# Altium schematic sheet PowerConnections.SchDoc
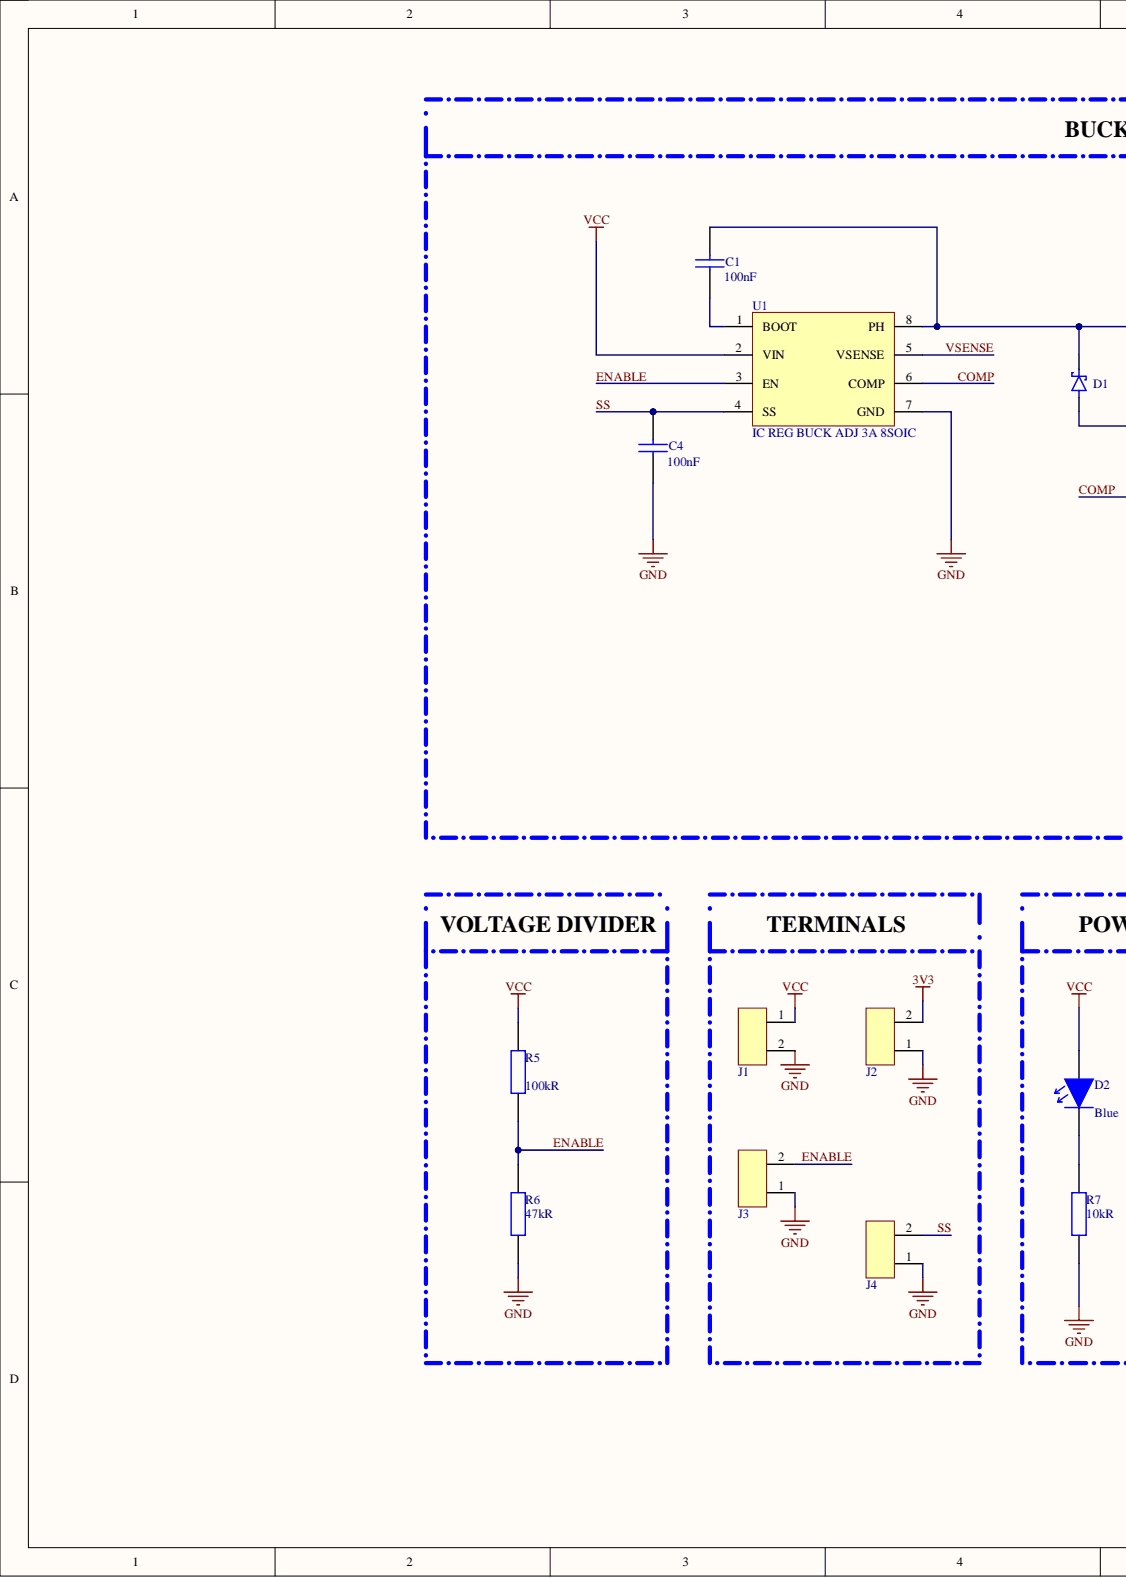
[diagram p0: PowerConnections.SchDoc, left]
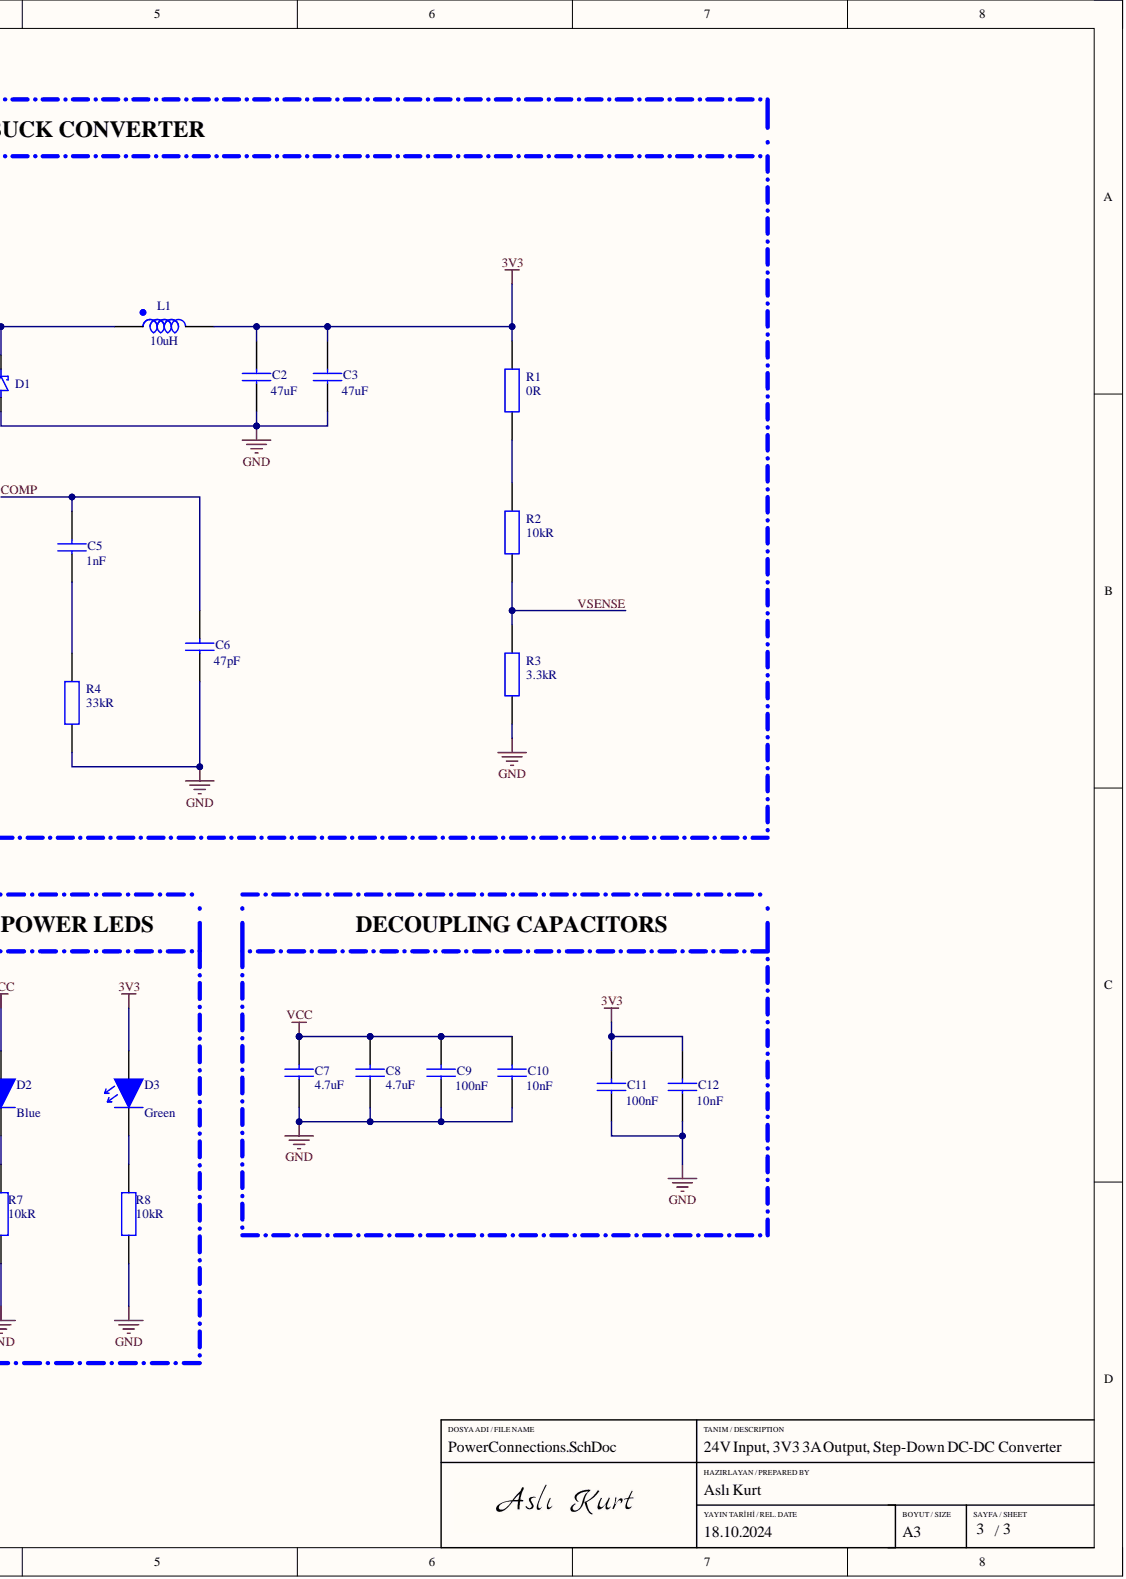
[diagram p1: PowerConnections.SchDoc, right]

## Parts
C1  Capacitance: 100nF  [CAP_1206]  (hidden comment: C1206C104J5RAC7800)
C2  Capacitance: 47uF  [CAP_1206]  (hidden comment: GMC31X5R476M25NT)
C3  Capacitance: 47uF  [CAP_1206]  (hidden comment: GMC31X5R476M25NT)
C4  Capacitance: 100nF  [CAP_1206]  (hidden comment: C1206C104J5RAC7800)
C5  Capacitance: 1nF  [CAP_1206]  (hidden comment: C3216C0G2J102J085AA)
C6  Capacitance: 47pF  [CAP_1206]  (hidden comment: C1206C470J5GAC7800)
C7  Capacitance: 4.7uF  [CAP_0805]  (hidden comment: CL21A475KAQNNNE)
C8  Capacitance: 4.7uF  [CAP_0805]  (hidden comment: CL21A475KAQNNNE)
C9  Capacitance: 100nF  [CAP_1206]  (hidden comment: C1206C104J5RAC7800)
C10  Capacitance: 10nF  [CAP_1206]  (hidden comment: C3216C0G2J103J160AA)
C11  Capacitance: 100nF  [CAP_1206]  (hidden comment: C1206C104J5RAC7800)
C12  Capacitance: 10nF  [CAP_1206]  (hidden comment: C3216C0G2J103J160AA)
D1  B340AE-13  [SMA]  (value not drawn)
D2  Color: Blue  [LED_BLUE_1206]  (hidden comment: 150120BS75000)
D3  Color: Green  [LED_GREEN_1206]  (hidden comment: 150120GS75000)
J1  282836-2  [TERMINAL]  (value not drawn)
J2  282836-2  [TERMINAL]  (value not drawn)
J3  2x1 Male Header 2.54mm  [2x1 Male Header 2.54mm]  (value not drawn)
J4  2x1 Male Header 2.54mm  [2x1 Male Header 2.54mm]  (value not drawn)
L1  Inductance: 10uH  [IND_1010]  (hidden comment: SRI1004)
R1  Resistance: 0R  [RES_1206]  (hidden comment: PMR18EZPJ000)
R2  Resistance: 10kR  [RES_1206]  (hidden comment: RC1206JR-0710KL)
R3  Resistance: 3.3kR  [RES_1206]  (hidden comment: RC1206JR-073K3L)
R4  Resistance: 33kR  [RES_1206]  (hidden comment: RES 33K OHM 5% 1/4W 1206)
R5  Resistance: 100kR  [RES_1206]  (hidden comment: RC1206JR-07100KL)
R6  Resistance: 47kR  [RES_1206]  (hidden comment: RES 47K OHM 5% 1/4W 1206)
R7  Resistance: 10kR  [RES_1206]  (hidden comment: RC1206JR-0710KL)
R8  Resistance: 10kR  [RES_1206]  (hidden comment: RC1206JR-0710KL)
U1  IC REG BUCK ADJ 3A 8SOIC  Max Output Current: 3A  [SOIC8_PAD]

## Nets
3V3: C2.2 C3.2 C11.2 C12.2 D3.2 J2.2 L1.2 R1.2
GND: C2.1 C3.1 C4.1 C6.1 C7.1 C8.1 C9.1 C10.1 C11.1 C12.1 D1.1 J1.2 J2.1 J3.1 J4.1 R3.1 R4.1 R6.1 R7.1 R8.1 U1.7(GND)
VCC: C7.2 C8.2 C9.2 C10.2 D2.2 J1.1 R5.2 U1.2(VIN)
COMP: C5.2 C6.2 U1.6(COMP)
ENABLE: J3.2 R5.1 R6.2 U1.3(EN)
SS: C4.2 J4.2 U1.4(SS)
VSENSE: R2.1 R3.2 U1.5(VSENSE)
NetC1_1: C1.1 U1.1(BOOT)
NetC1_2: C1.2 D1.2 L1.1 U1.8(PH)
NetC5_1: C5.1 R4.2
NetD2_1: D2.1 R7.2
NetD3_1: D3.1 R8.2
NetR1_1: R1.1 R2.2

## Library data of the parts
C1 C4 C9 C11 = CAP CER 100nF 1206: CAP CER 0.1UF 50V X7R 1206; Manufacturer: Kyocera AVX; Manufacturer Part Number: 12065C104JAT2A; DesignedBy: Aslı Kurt; Material: 4241ConductorMaterial; Tolerance: 5%; Case Code (Metric): 3216; Case Code (Imperial): 1206; Case/Package: 1206; Category: Ceramic Capacitors; Component Kind: Standard; ComponentLink1Description: https://datasheet.ciiva.com/26915/getdatasheetpartid-87553-26915356.pdf?src-supplier=Verical; ComponentLink1URL: https://datasheet.ciiva.com/26915/getdatasheetpartid-87553-26915356.pdf?src-supplier=Verical; ComponentLink2Description: https://datasheet.ciiva.com/27747/740463-27747550.pdf?src-supplier=Jameco; ComponentLink2URL: https://datasheet.ciiva.com/27747/740463-27747550.pdf?src-supplier=Jameco; ComponentLink3Description: https://datasheet.ciiva.com/7094/1818074-7094222.pdf?src-supplier=Newark; ComponentLink3URL: https://datasheet.ciiva.com/7094/1818074-7094222.pdf?src-supplier=Newark; ComponentLink4Description: https://datasheet.ciiva.com/11463/cx7r-11463226.pdf?src-supplier=Avnet; ComponentLink4URL: https://datasheet.ciiva.com/11463/cx7r-11463226.pdf?src-supplier=Avnet; ComponentLink5Description: https://datasheet.ciiva.com/16318/cx7r-16318250.pdf?src-supplier=Future+Electronics; ComponentLink5URL: https://datasheet.ciiva.com/16318/cx7r-16318250.pdf?src-supplier=Future+Electronics; ComponentLink6Description: https://datasheet.ciiva.com/11505/cx7r-11505336.pdf?src-supplier=Avnet; ComponentLink6URL: https://datasheet.ciiva.com/11505/cx7r-11505336.pdf?src-supplier=Avnet; ComponentLink7Description: https://datasheet.ciiva.com/7833/84526-7833657.pdf?src-supplier=Element14; ComponentLink7URL: https://datasheet.ciiva.com/7833/84526-7833657.pdf?src-supplier=Element14; ComponentLink8Description: https://datasheet.ciiva.com/8081/1679506-8081368.pdf?src-supplier=Element14; ComponentLink8URL: https://datasheet.ciiva.com/8081/1679506-8081368.pdf?src-supplier=Element14; ComponentLink9Description: https://datasheet.ciiva.com/7833/cx7r-7833399.pdf?src-supplier=Element14; ComponentLink9URL: https://datasheet.ciiva.com/7833/cx7r-7833399.pdf?src-supplier=Element14; ComponentLink10Description: https://datasheet.ciiva.com/152/cx7r-152041.pdf?src-supplier=Digikey; ComponentLink10URL: https://datasheet.ciiva.com/152/cx7r-152041.pdf?src-supplier=Digikey; ComponentLink11Description: https://datasheet.ciiva.com/152/cx7r-152040.pdf?src-supplier=Digikey; ComponentLink11URL: https://datasheet.ciiva.com/152/cx7r-152040.pdf?src-supplier=Digikey; ComponentLink12Description: https://datasheet.ciiva.com/152/cx7r-152042.pdf?src-supplier=Digikey; ComponentLink12URL: https://datasheet.ciiva.com/152/cx7r-152042.pdf?src-supplier=Digikey; ComponentLink13Description: https://datasheet.ciiva.com/14604/70001075-14604380.pdf?src-supplier=Allied+Electronics; ComponentLink13URL: https://datasheet.ciiva.com/14604/70001075-14604380.pdf?src-supplier=Allied+Electronics; Dielectric: X7R; Height: 0.5mm; Lead Free: 5697LeadFree; Length: 3.2mm; Library Name: Capacitors.IntLib; Max Operating Temperature: 125°C; Min Operating Temperature: -55°C; Mount: Surface Mount; Package Quantity: 4000; Packaging: Cut Tape; Pricing 1: 4000=0,049, 12000=0,048 (GBP); Pricing 2: 4000=0,04296 (USD); Pricing 3: 4000=0,0373, 12000=0,0364, 20000=0,036, 60000=0,0351, 100000=0,0344 (USD); Pricing 4: 1=0,22, 10=0,105, 50=0,105, 100=0,066, 1000=0,062, 10000=0,041 (USD); RoHS: Yes; RoHS Compliant: Yes; Series: -; Stock 1: 8700; Stock 2: 40000; Stock 3: 916000; Stock 4: 79343; Supplier 1: Rs; Supplier 2: Avnet; Supplier 3: Future Electronics; Supplier 4: Mouser; Supplier Part Number 1: 1441626; Supplier Part Number 2: KGM31BR71H104JT; Supplier Part Number 3: 1247949; Supplier Part Number 4: 581-12065C104J; Termination: SMD/SMT; Voltage Rating: 50V; Voltage Rating (DC): 50V; Weight: 0.000571oz; Width: 1.6mm
C2 C3 = CAP CER 47uF 1206: CAP CER 47UF 25V X5R 1206; Manufacturer: Cal-Chip Electronics; DesignedBy: Aslı Kurt; Tolerance: 20%; Case/Package: 1206; Category: Ceramic Capacitors; Component Kind: Standard; ComponentLink1Description: http://www.calchipelectronics.com/pdf/gmc_series.pdf?src-supplier=Digikey; ComponentLink1URL: http://www.calchipelectronics.com/pdf/gmc_series.pdf?src-supplier=Digikey; Library Name: Capacitors.IntLib; Manufacturer Part Number: GMC31X5R476M25NT; Max Operating Temperature: 85°C; Min Operating Temperature: -55°C; Mount: Surface Mount; Pricing 1: 1=0,99, 10=0,613, 50=0,4602, 100=0,4126, 500=0,33138, 1000=0,30602 (USD); Pricing 2: 1=0,99, 10=0,613, 50=0,4602, 100=0,4126, 500=0,33138, 1000=0,30602 (USD); Pricing 3: 2000=0,28788, 4000=0,27032, 6000=0,26149, 10000=0,25165, 14000=0,24588, 20000=0,24032 (USD); RoHS: Yes; Series: GMC; Stock 1: 38887; Stock 2: 38887; Stock 3: 38000; Supplier 1: Digikey; Supplier 2: Digikey; Supplier 3: Digikey; Supplier Part Number 1: 4713-GMC31X5R476M25NTCT-ND; Supplier Part Number 2: 4713-GMC31X5R476M25NTDKR-ND; Supplier Part Number 3: 4713-GMC31X5R476M25NTTR-ND; Voltage Rating: 25V
C5 = CAP CER 1nF 1206: Manufacturer: TDK; DesignedBy: Aslı Kurt; Material: 4241ConductorMaterial; Category: Ceramic Capacitors; Component Kind: Standard; ComponentLink1Description: https://datasheet.ciiva.com/24219/c3216c0g2j102j085aa-265301-24219668.pdf?src-supplier=Mouser; ComponentLink1URL: https://datasheet.ciiva.com/24219/c3216c0g2j102j085aa-265301-24219668.pdf?src-supplier=Mouser; ComponentLink2Description: https://datasheet.ciiva.com/11788/0900766b80fc5cba-11788148.pdf?src-supplier=Rs; ComponentLink2URL: https://datasheet.ciiva.com/11788/0900766b80fc5cba-11788148.pdf?src-supplier=Rs; ComponentLink3Description: https://datasheet.ciiva.com/7726/1484596-7726604.pdf?src-supplier=Element14; ComponentLink3URL: https://datasheet.ciiva.com/7726/1484596-7726604.pdf?src-supplier=Element14; Description: CAP CER 1nF 1206; Library Name: Capacitors.IntLib; Manufacturer Part Number: C3216C0G2J102J085AA; Pricing 1: 1=0,26, 10=0,115, 50=0,115, 100=0,072, 1000=0,062, 10000=0,048 (USD); Pricing 2: 1=0,27, 10=0,154, 50=0,1098, 100=0,0961, 500=0,07266, 1000=0,06537 (USD); RoHS: Yes; Stock 1: 6821; Stock 2: 72988; Supplier 1: Mouser; Supplier 2: Digikey; Supplier Part Number 1: 810-C3216C0G2J102J; Supplier Part Number 2: 445-2342-1-ND
C6 = CAP CER 47pF 1206: CAP CER 47PF 50V C0G/NP0 1206; Manufacturer: KEMET; Manufacturer Part Number: C1206C470J5GACTU; Dielectric: C0G; Dielectric Material: Ceramic; Dissipation Factor: 0.1%; Material: 4241ConductorMaterial; Temperature Coefficient: 30ppm/°C; Tolerance: 5%; Case Code (Metric): 3216; Case Code (Imperial): 1206; Case/Package: 1206; Category: Ceramic Capacitors; Component Kind: Standard; ComponentLink1Description: https://datasheet.ciiva.com/7729/1691965-7729914.pdf?src-supplier=Element14; ComponentLink1URL: https://datasheet.ciiva.com/7729/1691965-7729914.pdf?src-supplier=Element14; ComponentLink2Description: https://datasheet.ciiva.com/7729/1691965-7729913.pdf?src-supplier=Element14; ComponentLink2URL: https://datasheet.ciiva.com/7729/1691965-7729913.pdf?src-supplier=Element14; ComponentLink3Description: https://datasheet.ciiva.com/28668/1789732-28668879.pdf?src-supplier=Element14; ComponentLink3URL: https://datasheet.ciiva.com/28668/1789732-28668879.pdf?src-supplier=Element14; ComponentLink4Description: https://datasheet.ciiva.com/14598/70095383-14598902.pdf?src-supplier=Allied+Electronics; ComponentLink4URL: https://datasheet.ciiva.com/14598/70095383-14598902.pdf?src-supplier=Allied+Electronics; ComponentLink5Description: https://datasheet.ciiva.com/14598/70095383-14598719.pdf?src-supplier=Allied+Electronics; ComponentLink5URL: https://datasheet.ciiva.com/14598/70095383-14598719.pdf?src-supplier=Allied+Electronics; ComponentLink6Description: https://datasheet.ciiva.com/11463/kem-c1003-c0g-smd-11463659.pdf?src-supplier=Avnet; ComponentLink6URL: https://datasheet.ciiva.com/11463/kem-c1003-c0g-smd-11463659.pdf?src-supplier=Avnet; ComponentLink7Description: https://datasheet.ciiva.com/2988/kem-c1003-c0g-smd-2988767.pdf?src-supplier=Avnet; ComponentLink7URL: https://datasheet.ciiva.com/2988/kem-c1003-c0g-smd-2988767.pdf?src-supplier=Avnet; Construction: Flat; Depth: 1.6mm; Height: 0.78mm; Lead Free: 5697LeadFree; Length: 3.2mm; Library Name: Capacitors.IntLib; Max Operating Temperature: 125°C; Min Operating Temperature: -55°C; Mount: Surface Mount,PCB; Package Quantity: 1; Packaging: Tape and Reel; Pricing 1: 1=0,18, 10=0,089, 50=0,089, 100=0,051, 1000=0,035, 10000=0,026 (USD); Pricing 2: 500=0,022, 1000=0,019, 1500=0,017 (GBP); Pricing 3: 4000=0,0232, 8000=0,021, 24000=0,02 (USD); Pricing 4: 1=0,2, 10=0,112, 50=0,0788, 100=0,0685, 500=0,05114, 1000=0,04574 (USD); Reach SVHC Compliant: No; RoHS: Yes; RoHS Compliant: Yes; Series: C; Stock 1: 9133; Stock 2: 8000; Stock 3: 12000; Stock 4: 41465; Supplier 1: Mouser; Supplier 2: Rs; Supplier 3: Arrow Electronics; Supplier 4: Digikey; Supplier Part Number 1: 80-C1206C470J5GACTU; Supplier Part Number 2: 8029999; Supplier Part Number 3: C1206C470J5GACTU; Supplier Part Number 4: 399-C1206C470J5GAC7800CT-ND; Termination: SMD/SMT; Thickness: 0.035inch; Voltage: 50V; Voltage Rating: 50V; Voltage Rating (AC): 50V; Voltage Rating (DC): 50V; Width: 0.063inch
C7 C8 = CAP CER 4.7uF 0805: CAP CER 4.7uF %10 0805; Manufacturer: Samsung; Designed by: BERK; Dielectric: X5R; Tolerance: 10%; Voltage Rating: 25V; Case Code (Metric): 2012; Case Code (Imperial): 0805; Case/Package: 0805; Category: Ceramic Capacitors; Component Kind: Standard; ComponentLink1Description: https://datasheet.ciiva.com/25798/cl21a475kaqnnne-25798882.pdf?src-supplier=Digi-Key; ComponentLink1URL: https://datasheet.ciiva.com/25798/cl21a475kaqnnne-25798882.pdf?src-supplier=Digi-Key; Construction: Flat; Depth: 1.25mm; Height: 1.25mm; Lead Free: Lead Free; Length: 2mm; Library Name: Capacitors.IntLib; Manufacturer Part Number: CL21A475KAQNNNE; Max Operating Temperature: 85°C; Min Operating Temperature: -55°C; Mount: Surface Mount; Package Quantity: 1; Packaging: Tape and Reel; Pricing 1: 1=0,11000, 10=0,08100, 50=0,04580, 100=0,03800, 500=0,02664, 1000=0,02205 (USD); Radiation Hardening: No; RoHS: Yes; RoHS Compliant: Yes; Series: CL; Stock 1: 1477018; Supplier 1: Digi-Key; Supplier Part Number 1: 1276-1244-1-ND; Thickness: 0.055inch; Voltage Rating (DC): 25V; Width: 0.049inch
C10 C12 = CAP CER 10nF 1206: Manufacturer: TDK; DesignedBy: Aslı Kurt; Material: 4241ConductorMaterial; Temperature Coefficient: 30ppm/°C; Category: Ceramic Capacitors; Component Kind: Standard; ComponentLink1Description: http://www.mouser.com/ds/2/400/lcc_commercial_highvoltage_en-520036.pdf?src-supplier=Mouser; ComponentLink1URL: http://www.mouser.com/ds/2/400/lcc_commercial_highvoltage_en-520036.pdf?src-supplier=Mouser; ComponentLink2Description: https://datasheet.octopart.com/C3216C0G2J103J160AA-TDK-datasheet-43914167.pdf?src-supplier=Newark; ComponentLink2URL: https://datasheet.octopart.com/C3216C0G2J103J160AA-TDK-datasheet-43914167.pdf?src-supplier=Newark; ComponentLink3Description: https://product.tdk.com/info/en/documents/chara_sheet/C3216C0G2J103J160AA.pdf?src-supplier=Digikey; ComponentLink3URL: https://product.tdk.com/info/en/documents/chara_sheet/C3216C0G2J103J160AA.pdf?src-supplier=Digikey; ComponentLink4Description: https://product.tdk.com/info/en/catalog/spec/mlccspec_commercial_general_midvoltage_en.pdf?src-supplier=Digikey; ComponentLink4URL: https://product.tdk.com/info/en/catalog/spec/mlccspec_commercial_general_midvoltage_en.pdf?src-supplier=Digikey; ComponentLink5Description: http://product.tdk.com/en/catalog/datasheets/mlcc_commercial_midvoltage_en.pdf?src-supplier=Avnet; ComponentLink5URL: http://product.tdk.com/en/catalog/datasheets/mlcc_commercial_midvoltage_en.pdf?src-supplier=Avnet; ComponentLink6Description: https://datasheet.octopart.com/C3216C0G2J103J160AA-TDK-datasheet-41194876.pdf?src-supplier=Future+Electronics; ComponentLink6URL: https://datasheet.octopart.com/C3216C0G2J103J160AA-TDK-datasheet-41194876.pdf?src-supplier=Future+Electronics; Description: CAP CER 10nF 1206; Lead Free: 5697LeadFree; Library Name: Capacitors.IntLib; Manufacturer Part Number: C3216C0G2J103J160AA; Pricing 1: 2000=0,092, 6000=0,095 (GBP); Pricing 2: 1=0,53, 10=0,316, 50=0,231, 100=0,2046, 500=0,1596, 1000=0,14555 (USD); Pricing 3: 264=0,1004 (USD); Pricing 4: 1=0,405, 15=0,32, 50=0,285, 250=0,235, 1000=0,195 (USD); RoHS: Yes; Stock 1: 9940; Stock 2: 35379; Stock 3: 264; Stock 4: 1420; Supplier 1: Rs; Supplier 2: Digikey; Supplier 3: Arrow Electronics; Supplier 4: Future Electronics; Supplier Part Number 1: 1795135; Supplier Part Number 2: 445-14625-1-ND; Supplier Part Number 3: C3216C0G2J103J160AA; Supplier Part Number 4: 6103616
D1 = B340A: Rectifier Diode Schottky 40V 3A 2-Pin SMA T/R; Manufacturer: Diodes; DesignedBy: Aslı Kurt; Category: Schottky Diodes; Component Kind: Standard; ComponentLink1Description: https://www.diodes.com/assets/Datasheets/B320AE-B345AE.pdf?src-supplier=Digikey; ComponentLink1URL: https://www.diodes.com/assets/Datasheets/B320AE-B345AE.pdf?src-supplier=Digikey; Forward Current: 3A; Library Name: Diodes.IntLib; Manufacturer Part Number: B340AE-13; Pricing 1: 1=0,37, 10=0,248, 100=0,1671, 500=0,1296, 1000=0,1171, 2000=0,10643 (USD); Pricing 2: 1=0,37, 10=0,248, 100=0,1671, 500=0,1296, 1000=0,1171, 2000=0,10643 (USD); RoHS: Yes; Stock 1: 232201; Stock 2: 232201; Supplier 1: Digikey; Supplier 2: Digikey; Supplier Part Number 1: B340AE-13DICT-ND; Supplier Part Number 2: B340AE-13DIDKR-ND
D2 = LED BLUE 1206: Led, Blue, 470 Nm, 3.2 V, 30 Ma, 145 Mcd Rohs Compliant: Yes |Wurth Elektronik 150120BS75000; Manufacturer: Wurth Electronics; DesignedBy: Aslı Kurt; Dominant Wavelength: 470nm; Forward Current: 30mA; Forward Voltage: 3.2V; Lens Color: Colorless; Lens Size: 1.6mm; Lens Style: Clear,Flat,Rectangular; Lens Transparency: Clear; Luminous Intensity: 145mcd; Test Current: 20mA; Viewing Angle: 140°; Case Code (Metric): 3216; Case Code (Imperial): 1206; Case/Package: 1206; Category: LEDs; Component Kind: Standard; ComponentLink1Description: https://datasheet.ciiva.com/22656/150120bs75000-22656976.pdf?src-supplier=Element14; ComponentLink1URL: https://datasheet.ciiva.com/22656/150120bs75000-22656976.pdf?src-supplier=Element14; ComponentLink2Description: https://datasheet.ciiva.com/29279/759440-da-01-en-chipled-1206-w-kl-blau-150120bs75000-29279045.pdf?src-supplier=Conrad; ComponentLink2URL: https://datasheet.ciiva.com/29279/759440-da-01-en-chipled-1206-w-kl-blau-150120bs75000-29279045.pdf?src-supplier=Conrad; ComponentLink3Description: https://datasheet.ciiva.com/29561/150120bs75000-29561882.pdf?src-supplier=Digikey; ComponentLink3URL: https://datasheet.ciiva.com/29561/150120bs75000-29561882.pdf?src-supplier=Digikey; Height: 0.69mm; Length: 3.2mm; Library Name: Diodes.IntLib; Manufacturer Part Number: 150120BS75000; Max Operating Temperature: 85°C; Min Operating Temperature: -40°C; Mount: Surface Mount; Package Quantity: 1; Packaging: Cut Tape; Pricing 1: 500=0,119, 750=0,113, 1500=0,11, 3000=0,104 (GBP); Pricing 2: 5=0,251, 10=0,213, 100=0,172, 500=0,156, 1000=0,153 (EUR); Pricing 3: 1=0,22, 50=0,211, 100=0,182, 500=0,158 (USD); Pricing 4: 1=0,23, 10=0,225, 50=0,211, 100=0,182, 1000=0,165, 10000=0,165 (USD); Reach SVHC Compliant: Unknown; RoHS: Yes; RoHS Compliant: Yes; Series: WL-SMCW; Stock 1: 2200; Stock 2: 4482; Stock 3: 25240; Stock 4: 4085; Supplier 1: Rs; Supplier 2: Farnell; Supplier 3: Digikey; Supplier 4: Mouser; Supplier Part Number 1: 8154243P; Supplier Part Number 2: 2322084; Supplier Part Number 3: 732-4989-1-ND; Supplier Part Number 4: 710-150120BS75000; Wavelength: 470nm; Width: 1.6mm
D3 = LED GREEN 1206: WL-SMCW SMT Mono-Color Chip LED Waterclear; Manufacturer: Wurth Electronics; DesignedBy: Aslı Kurt; Dominant Wavelength: 520nm; Forward Current: 30mA; Forward Voltage: 3.2V; Lens Color: Colorless; Lens Size: 1.6mm; Lens Style: Clear,Flat,Rectangular; Lens Transparency: Clear; Luminous Intensity: 450mcd; Max Reverse Voltage (DC): 5V; Power Dissipation: 105mW; Test Current: 20mA; Viewing Angle: 140°; Case Code (Metric): 3216; Case Code (Imperial): 1206; Case/Package: 1206; Category: LEDs; Component Kind: Standard; ComponentLink1Description: https://datasheet.ciiva.com/22656/150120gs75000-22656979.pdf?src-supplier=Element14; ComponentLink1URL: https://datasheet.ciiva.com/22656/150120gs75000-22656979.pdf?src-supplier=Element14; ComponentLink2Description: https://datasheet.ciiva.com/29279/759441-da-01-en-chipled-1206-w-kl-gruen-150120gs75000-29279042.pdf?src-supplier=Conrad; ComponentLink2URL: https://datasheet.ciiva.com/29279/759441-da-01-en-chipled-1206-w-kl-gruen-150120gs75000-29279042.pdf?src-supplier=Conrad; ComponentLink3Description: https://datasheet.ciiva.com/48430/150120gs75000-eng-tds-48430053.pdf?src-supplier=Distrelec; ComponentLink3URL: https://datasheet.ciiva.com/48430/150120gs75000-eng-tds-48430053.pdf?src-supplier=Distrelec; ComponentLink4Description: https://datasheet.ciiva.com/29561/150120gs75000-29561884.pdf?src-supplier=Digikey; ComponentLink4URL: https://datasheet.ciiva.com/29561/150120gs75000-29561884.pdf?src-supplier=Digikey; ComponentLink5Description: https://datasheet.ciiva.com/29561/150120gs75000-29561883.pdf?src-supplier=Digikey; ComponentLink5URL: https://datasheet.ciiva.com/29561/150120gs75000-29561883.pdf?src-supplier=Digikey; ComponentLink6Description: https://datasheet.ciiva.com/29561/150120gs75000-29561885.pdf?src-supplier=Digikey; ComponentLink6URL: https://datasheet.ciiva.com/29561/150120gs75000-29561885.pdf?src-supplier=Digikey; Height: 0.69mm; Length: 3.2mm; Library Name: Diodes.IntLib; Manufacturer Part Number: 150120GS75000; Max Operating Temperature: 85°C; Min Operating Temperature: -40°C; Mount: Surface Mount; Package Quantity: 1; Packaging: Cut Tape; Pricing 1: 500=0,119, 750=0,113, 1500=0,11, 3000=0,104 (GBP); Pricing 2: 100=0,172, 500=0,156, 1000=0,153 (EUR); Pricing 3: 1=0,23, 10=0,225, 50=0,211, 100=0,182, 1000=0,165, 10000=0,165 (USD); Pricing 4: 1=0,22, 50=0,211, 100=0,182, 500=0,158 (USD); Reach SVHC Compliant: Unknown; Reverse Voltage: 5V; RoHS: Yes; RoHS Compliant: Yes; Series: WL-SMCW; Stock 1: 4450; Stock 2: 6145; Stock 3: 4986; Stock 4: 22547; Supplier 1: Rs; Supplier 2: Farnell; Supplier 3: Mouser; Supplier 4: Digikey; Supplier Part Number 1: 8154247P; Supplier Part Number 2: 2322085RL; Supplier Part Number 3: 710-150120GS75000; Supplier Part Number 4: 732-4990-1-ND; Wavelength: 520nm; Width: 1.6mm
J1 J2 = 2x1 TERMINAL 5mm: Connector, Terminal Block; Wire Receptacle; 2 Pos.; 16-30 AWG; 0.197 in. CL; Sid; Manufacturer: TE Connectivity; Approvals: UL; Closed End: No (Without); Connector System: 5937ConnectorSystem; Current: 13.5A; Designed By: Aslı Kurt; Max Supply Voltage (AC): 300V; Number of Circuits: 2; Number of Levels: 1; Pitch: 5mm; Plating: Brass; Side Stackable: Yes (With); Tail Length: 3.5mm; Thread Size: M3; Voltage Rating (DC): 250V; Wire Gauge (Max): 30AWG; Category: Terminal Blocks; Color: Green; Component Kind: Standard; ComponentLink1Description: https://datasheet.ciiva.com/7628/1731782-7628513.pdf?src-supplier=Element14; ComponentLink1URL: https://datasheet.ciiva.com/7628/1731782-7628513.pdf?src-supplier=Element14; ComponentLink2Description: https://datasheet.ciiva.com/16393/282836-2-16393382.pdf?src-supplier=Future+Electronics; ComponentLink2URL: https://datasheet.ciiva.com/16393/282836-2-16393382.pdf?src-supplier=Future+Electronics; ComponentLink3Description: https://datasheet.ciiva.com/15169/getdatasheetpartid-155855-15169553.pdf?src-supplier=Verical; ComponentLink3URL: https://datasheet.ciiva.com/15169/getdatasheetpartid-155855-15169553.pdf?src-supplier=Verical; ComponentLink4Description: https://datasheet.ciiva.com/11757/0900766b8002dc8a-11757385.pdf?src-supplier=Rs; ComponentLink4URL: https://datasheet.ciiva.com/11757/0900766b8002dc8a-11757385.pdf?src-supplier=Rs; ComponentLink5Description: https://datasheet.ciiva.com/823/eng-cd-282836-h10-823437.pdf?src-supplier=Digikey; ComponentLink5URL: https://datasheet.ciiva.com/823/eng-cd-282836-h10-823437.pdf?src-supplier=Digikey; ComponentLink6Description: https://datasheet.ciiva.com/3141/eng-cs-1308389-eurostyle-terminal-blocks-0607-3141118.pdf?src-supplier=Avnet; ComponentLink6URL: https://datasheet.ciiva.com/3141/eng-cs-1308389-eurostyle-terminal-blocks-0607-3141118.pdf?src-supplier=Avnet; ComponentLink7Description: https://datasheet.ciiva.com/30261/eng-cs-1308389-eurostyle-terminal-blocks-0607-30261813.pdf?src-supplier=Onlinecomponents.com; ComponentLink7URL: https://datasheet.ciiva.com/30261/eng-cs-1308389-eurostyle-terminal-blocks-0607-30261813.pdf?src-supplier=Onlinecomponents.com; Connector Type: Receptacle; Contact Material: Brass; Contact Plating: Tin; Current Rating: 13.5A; Depth: 8.2mm; ELV: Compliant; Flammability Rating: UL94 V-0; Housing Color: Green; Housing Material: Polyamide; Lead Free: Lead Free; Length: 10.6mm; Library Name: Connectors.IntLib; Manufacturer Part Number: 282836-2; Max Current Rating: 13.5A; Max Operating Temperature: 105°C; Max Voltage Rating (AC): 300V; Min Operating Temperature: -40°C; Mount: Through Hole; Number of Contacts: 2; Number of Poles: 2; Number of Positions: 2; Number of Positions Per Level: 2; Number of Rows: 1; Operating Supply Voltage: 300V; Orientation: Straight; Package Quantity: 300; Packaging: Tray; Pricing 1: 1=0,6, 10=0,578, 25=0,576, 50=0,5566, 100=0,4666, 300=0,43743, 600=0,41315, 1200=0,36211, 5100=0,31593 (USD); Radiation Hardening: No; Reach SVHC Compliant: Unknown; RoHS: Yes; RoHS Compliant: Yes; Screw Flange: No (Without); Screwless Terminal Block: No (Without); Series: Buchanan; Stock 1: 33835; Supplier 1: Digikey; Supplier Part Number 1: A98076-ND; Termination: Solder; Voltage: 300V; Voltage Rating: 300V; Width: 10mm; Wire Gauge (Min): 30AWG; Wire/Cable Gauge: 30AWG; Insulation Material: Nylon; Interlock: Yes (With)
L1 = IND 10uH: 10uH 10X10 4.8A - SMD Güç Bobini - SRI1004; DesignedBy: Aslı Kurt; Producer: CoreMaster; Tolerance: 20%; Component Kind: Standard; ComponentLink1Description: Direncnet; ComponentLink1URL: https://www.direnc.net/10uh-10x10-48a-smd-guc-bobini-sri1004; ComponentLink2Description: Datasheet; ComponentLink2URL: https://pdf.direnc.net/upload/10uh-10x10-4-8a-smd-guc-bobini-sri1004-datasheet.pdf; Current: 4.8A; Library Name: Inductors.IntLib
R1 = RES 0 OHM 1206: Res Thick Film 1206 0 Ohm 5% Molded SMD Paper T/R; Manufacturer: Rohm; DesignedBy: Aslı Kurt; Max Current Rating: 38.7A; Temperature Coefficient: 100ppm/°C; Case/Package: 340Case; Category: Chip SMD Resistors; Component Kind: Standard; ComponentLink1Description: https://datasheet.octopart.com/PMR18EZPJ000-Rohm-datasheet-115132289.pdf?src-supplier=Future+Electronics; ComponentLink1URL: https://datasheet.octopart.com/PMR18EZPJ000-Rohm-datasheet-115132289.pdf?src-supplier=Future+Electronics; Height: 420um; Library Name: Resistors.IntLib; Manufacturer Part Number: PMR18EZPJ000; Max Operating Temperature: 155°C; Min Operating Temperature: -55°C; Pricing 1: 5000=0,154, 10000=0,151, 15000=0,149, 20000=0,148, 25000=0,144 (USD); Pricing 2: 1=0,94, 10=0,539, 25=0,438, 50=0,3766, 100=0,3254, 250=0,27044, 500=0,23676, 1000=0,20858 (USD); RoHS: Yes; Stock 1: 10000; Stock 2: 20524; Supplier 1: Future Electronics; Supplier 2: Digikey; Supplier Part Number 1: 3099053; Supplier Part Number 2: 846-PMR18EZPJ000CT-ND
R2 = RES 10K OHM 1206: RES 10K OHM 5% 1/4W 1206; Manufacturer: Yageo; DesignedBy: Aslı Kurt; Max Power Dissipation: 250mW; Temperature Coefficient: 100ppm/°C; Voltage Rating: 200V; Case Code (Metric): 3216; Case Code (Imperial): 1206; Case/Package: 1206; Category: Chip SMD Resistors; Component Kind: Standard; ComponentLink1Description: https://datasheet.ciiva.com/29154/402664-da-01-en-smd-widerstand-chip1206-10-kohm-29154546.pdf?src-supplier=Conrad; ComponentLink1URL: https://datasheet.ciiva.com/29154/402664-da-01-en-smd-widerstand-chip1206-10-kohm-29154546.pdf?src-supplier=Conrad; ComponentLink2Description: https://datasheet.ciiva.com/7983/444357-7983813.pdf?src-supplier=Element14; ComponentLink2URL: https://datasheet.ciiva.com/7983/444357-7983813.pdf?src-supplier=Element14; ComponentLink3Description: https://datasheet.ciiva.com/2458/pyu-rc1206-51-rohs-l-4-2458636.pdf?src-supplier=Digikey; ComponentLink3URL: https://datasheet.ciiva.com/2458/pyu-rc1206-51-rohs-l-4-2458636.pdf?src-supplier=Digikey; ComponentLink4Description: https://datasheet.ciiva.com/2458/pyu-rc1206-51-rohs-l-4-2458637.pdf?src-supplier=Digikey; ComponentLink4URL: https://datasheet.ciiva.com/2458/pyu-rc1206-51-rohs-l-4-2458637.pdf?src-supplier=Digikey; ComponentLink5Description: https://datasheet.ciiva.com/2458/pyu-rc1206-51-rohs-l-4-2458635.pdf?src-supplier=Digikey; ComponentLink5URL: https://datasheet.ciiva.com/2458/pyu-rc1206-51-rohs-l-4-2458635.pdf?src-supplier=Digikey; Height: 0.026inch; Lead Free: Lead Free; Length: 0.122inch; Library Name: Resistors.IntLib; Manufacturer Part Number: RC1206JR-0710KL; Max Operating Temperature: 155°C; Min Operating Temperature: -55°C; Number of Terminations: 2; Package Quantity: 1; Packaging: Cut Tape; Power Rating: 250mW; Pricing 1: 5000=0,0034, 20000=0,0033, 75000=0,0031, 200000=0,003, 500000=0,0029 (USD); Pricing 2: 1=0,1, 10=0,024, 50=0,022, 100=0,0194, 500=0,01224, 1000=0,0102 (USD); Reach SVHC Compliant: Unknown; Resistance Tolerance: 5%; Resistor Type: Thick Film; RoHS: Yes; RoHS Compliant: Yes; Series: RC1206; Stock 1: 145000; Stock 2: 179700; Supplier 1: Future Electronics; Supplier 2: Digikey; Supplier Part Number 1: 4750733; Supplier Part Number 2: 311-10KERCT-ND; Termination: SMD/SMT; Tolerance: 5%; Voltage Rating (AC): 200V; Width: 0.063inch
R3 = RES 3K3 OHM 1206: RES 3.3K OHM 5% 1/4W 1206; Manufacturer: Yageo; DesignedBy: Aslı Kurt; Category: Chip SMD Resistors; Component Kind: Standard; ComponentLink1Description: https://datasheet.ciiva.com/29181/402605-da-01-en-smd-widerstand-chip1206-3-3-kohm-29181477.pdf?src-supplier=Conrad; ComponentLink1URL: https://datasheet.ciiva.com/29181/402605-da-01-en-smd-widerstand-chip1206-3-3-kohm-29181477.pdf?src-supplier=Conrad; ComponentLink2Description: https://datasheet.ciiva.com/2458/pyu-r-int-thick-7-2458662.pdf?src-supplier=Digikey; ComponentLink2URL: https://datasheet.ciiva.com/2458/pyu-r-int-thick-7-2458662.pdf?src-supplier=Digikey; ComponentLink3Description: https://datasheet.ciiva.com/2458/pyu-r-int-thick-7-2458663.pdf?src-supplier=Digikey; ComponentLink3URL: https://datasheet.ciiva.com/2458/pyu-r-int-thick-7-2458663.pdf?src-supplier=Digikey; ComponentLink4Description: https://datasheet.ciiva.com/2458/pyu-r-int-thick-7-2458664.pdf?src-supplier=Digikey; ComponentLink4URL: https://datasheet.ciiva.com/2458/pyu-r-int-thick-7-2458664.pdf?src-supplier=Digikey; ComponentLink5Description: https://datasheet.ciiva.com/7857/444357-7857499.pdf?src-supplier=Element14; ComponentLink5URL: https://datasheet.ciiva.com/7857/444357-7857499.pdf?src-supplier=Element14; ComponentLink6Description: https://datasheet.ciiva.com/7857/444357-7857500.pdf?src-supplier=Element14; ComponentLink6URL: https://datasheet.ciiva.com/7857/444357-7857500.pdf?src-supplier=Element14; Lead Free: 5697LeadFree; Library Name: Resistors.IntLib; Manufacturer Part Number: RC1206JR-073K3L; Pricing 1: 10=0,0172, 100=0,0107, 500=0,0094, 1000=0,0081, 2500=0,0059, 5000=0,0037, 25000=0,0036, 50000=0,0035 (EUR); Pricing 2: 1=0,1, 10=0,025, 50=0,0158, 100=0,0133, 500=0,0092, 1000=0,00796 (USD); RoHS: Yes; Stock 1: 157764; Stock 2: 317191; Supplier 1: Farnell; Supplier 2: Digikey; Supplier Part Number 1: 9240586; Supplier Part Number 2: 311-3.3KERCT-ND
R4 = RES 33K OHM 1206: SMD Chip Resistor, 33 kOhm, ï¿½ 5%, 250 mW, 1206 [3216 Metric], Thick Film, General Purpose; Manufacturer: Yageo; Manufacturer Part Number: RC1206JR-0733KL; DesignedBy: Aslı Kurt; Voltage Rating (AC): 200V; Category: Chip SMD Resistors; Component Kind: Standard; ComponentLink1Description: https://datasheet.ciiva.com/29181/402729-da-01-en-smd-widerstand-chip1206-33-kohm-29181369.pdf?src-supplier=Conrad; ComponentLink1URL: https://datasheet.ciiva.com/29181/402729-da-01-en-smd-widerstand-chip1206-33-kohm-29181369.pdf?src-supplier=Conrad; ComponentLink2Description: https://datasheet.ciiva.com/7857/444357-7857487.pdf?src-supplier=Element14; ComponentLink2URL: https://datasheet.ciiva.com/7857/444357-7857487.pdf?src-supplier=Element14; ComponentLink3Description: https://datasheet.ciiva.com/16747/pyu-rc1206-51-rohs-l-4-16747052.pdf?src-supplier=Future+Electronics; ComponentLink3URL: https://datasheet.ciiva.com/16747/pyu-rc1206-51-rohs-l-4-16747052.pdf?src-supplier=Future+Electronics; Lead Free: 5697LeadFree; Library Name: Resistors.IntLib; Pricing 1: 1=0,1, 10=0,023, 50=0,0184, 100=0,0133, 500=0,01122, 1000=0,00918 (USD); Pricing 2: 5000=0,0036, 20000=0,0035, 75000=0,0033, 200000=0,0032, 500000=0,0031 (USD); RoHS: Yes; Stock 1: 425111; Stock 2: 75000; Supplier 1: Digikey; Supplier 2: Future Electronics; Supplier Part Number 1: 311-33KERCT-ND; Supplier Part Number 2: 1124239
R5 = RES 100K OHM 1206 1/4W: SMD Chip Resistor, 100 kOhm, ï¿½ 5%, 250 mW, 1206 [3216 Metric], Thick Film, General Purpose; Manufacturer: Yageo; DesignedBy: Aslı Kurt; Voltage Rating (AC): 200V; Category: Chip SMD Resistors; Component Kind: Standard; ComponentLink1Description: https://datasheet.ciiva.com/2458/pyu-rc1206-51-rohs-l-4-2458650.pdf?src-supplier=Digikey; ComponentLink1URL: https://datasheet.ciiva.com/2458/pyu-rc1206-51-rohs-l-4-2458650.pdf?src-supplier=Digikey; ComponentLink2Description: https://datasheet.ciiva.com/7857/444357-7857423.pdf?src-supplier=Element14; ComponentLink2URL: https://datasheet.ciiva.com/7857/444357-7857423.pdf?src-supplier=Element14; ComponentLink3Description: https://datasheet.ciiva.com/2458/pyu-rc1206-51-rohs-l-4-2458651.pdf?src-supplier=Digikey; ComponentLink3URL: https://datasheet.ciiva.com/2458/pyu-rc1206-51-rohs-l-4-2458651.pdf?src-supplier=Digikey; ComponentLink4Description: https://datasheet.ciiva.com/2458/pyu-rc1206-51-rohs-l-4-2458652.pdf?src-supplier=Digikey; ComponentLink4URL: https://datasheet.ciiva.com/2458/pyu-rc1206-51-rohs-l-4-2458652.pdf?src-supplier=Digikey; Lead Free: 5697LeadFree; Library Name: MyLibrary.IntLib; Manufacturer Part Number: RC1206JR-07100KL; Pricing 1: 10=0,0133, 100=0,0123, 500=0,0111, 1000=0,0104, 2500=0,0095 (EUR); Pricing 2: 5000=0,0029, 25000=0,0027, 100000=0,0026, 250000=0,0026, 625000=0,0024 (USD); Pricing 3: 5000=0,00353, 10000=0,00345, 20000=0,00338, 30000=0,00331, 40000=0,00325 (USD); Pricing 4: 1=0,1, 10=0,014, 50=0,014, 100=0,013, 1000=0,011, 10000=0,004 (USD); Pricing 5: 5000=0,004 (USD); RoHS: Yes; Stock 1: 3980; Stock 2: 470000; Stock 3: 5000; Stock 4: 41748; Stock 5: 55000; Supplier 1: Farnell; Supplier 2: Future Electronics; Supplier 3: Avnet; Supplier 4: Mouser; Supplier 5: Newark; Supplier Part Number 1: 9240764; Supplier Part Number 2: 4725842; Supplier Part Number 3: RC1206JR-07100KL; Supplier Part Number 4: 603-RC1206JR-07100KL; Supplier Part Number 5: 12AC2282
R6 = RES 47K OHM 1206 1/4W: SMD Chip Resistor, 47 kOhm, ï¿½ 5%, 250 mW, 1206 [3216 Metric], Thick Film, General Purpose; Manufacturer: Yageo; Manufacturer Part Number: RC1206JR-0747KL; DesignedBy: Aslı Kurt; Voltage Rating (AC): 200V; Category: Chip SMD Resistors; Component Kind: Standard; ComponentLink1Description: https://datasheet.ciiva.com/29181/402745-da-01-en-smd-widerstand-chip1206-47-kohm-29181385.pdf?src-supplier=Conrad; ComponentLink1URL: https://datasheet.ciiva.com/29181/402745-da-01-en-smd-widerstand-chip1206-47-kohm-29181385.pdf?src-supplier=Conrad; ComponentLink2Description: https://datasheet.ciiva.com/2520/pyu-r-int-thick-7-2520698.pdf?src-supplier=Digikey; ComponentLink2URL: https://datasheet.ciiva.com/2520/pyu-r-int-thick-7-2520698.pdf?src-supplier=Digikey; ComponentLink3Description: https://datasheet.ciiva.com/2520/pyu-r-int-thick-7-2520699.pdf?src-supplier=Digikey; ComponentLink3URL: https://datasheet.ciiva.com/2520/pyu-r-int-thick-7-2520699.pdf?src-supplier=Digikey; ComponentLink4Description: https://datasheet.ciiva.com/2520/pyu-r-int-thick-7-2520700.pdf?src-supplier=Digikey; ComponentLink4URL: https://datasheet.ciiva.com/2520/pyu-r-int-thick-7-2520700.pdf?src-supplier=Digikey; ComponentLink5Description: https://datasheet.ciiva.com/16740/pyu-rc1206-51-rohs-l-4-16740793.pdf?src-supplier=Future+Electronics; ComponentLink5URL: https://datasheet.ciiva.com/16740/pyu-rc1206-51-rohs-l-4-16740793.pdf?src-supplier=Future+Electronics; Library Name: MyLibrary.IntLib; Pricing 1: 10=0,0143, 100=0,0133, 500=0,0114, 1000=0,0095, 2500=0,0093 (EUR); Pricing 2: 5000=0,00353, 30000=0,00331, 40000=0,00325 (USD); Pricing 3: 100=0,008, 1000=0,007, 2000=0,006 (GBP); Pricing 4: 1=0,005, 25=0,005, 50=0,005, 100=0,005, 250=0,005, 500=0,005, 1000=0,005 (USD); RoHS: Yes; Stock 1: 117; Stock 2: 65000; Stock 3: 4400; Stock 4: 9045; Supplier 1: Farnell; Supplier 2: Avnet; Supplier 3: Rs; Supplier 4: Newark; Supplier Part Number 1: 9240721; Supplier Part Number 2: RC1206JR-0747KL; Supplier Part Number 3: 2427219; Supplier Part Number 4: 49AK3906
R7 R8 = RES 10K OHM 1206 1/4W: RES 10K OHM 5% 1/4W 1206; Manufacturer: Yageo; DesignedBy: Aslı Kurt; Max Power Dissipation: 250mW; Temperature Coefficient: 100ppm/°C; Voltage Rating: 200V; Case Code (Metric): 3216; Case Code (Imperial): 1206; Case/Package: 1206; Category: Chip SMD Resistors; Component Kind: Standard; ComponentLink1Description: https://datasheet.ciiva.com/29154/402664-da-01-en-smd-widerstand-chip1206-10-kohm-29154546.pdf?src-supplier=Conrad; ComponentLink1URL: https://datasheet.ciiva.com/29154/402664-da-01-en-smd-widerstand-chip1206-10-kohm-29154546.pdf?src-supplier=Conrad; ComponentLink2Description: https://datasheet.ciiva.com/7983/444357-7983813.pdf?src-supplier=Element14; ComponentLink2URL: https://datasheet.ciiva.com/7983/444357-7983813.pdf?src-supplier=Element14; ComponentLink3Description: https://datasheet.ciiva.com/2458/pyu-rc1206-51-rohs-l-4-2458636.pdf?src-supplier=Digikey; ComponentLink3URL: https://datasheet.ciiva.com/2458/pyu-rc1206-51-rohs-l-4-2458636.pdf?src-supplier=Digikey; ComponentLink4Description: https://datasheet.ciiva.com/2458/pyu-rc1206-51-rohs-l-4-2458637.pdf?src-supplier=Digikey; ComponentLink4URL: https://datasheet.ciiva.com/2458/pyu-rc1206-51-rohs-l-4-2458637.pdf?src-supplier=Digikey; ComponentLink5Description: https://datasheet.ciiva.com/2458/pyu-rc1206-51-rohs-l-4-2458635.pdf?src-supplier=Digikey; ComponentLink5URL: https://datasheet.ciiva.com/2458/pyu-rc1206-51-rohs-l-4-2458635.pdf?src-supplier=Digikey; Height: 0.026inch; Lead Free: Lead Free; Length: 0.122inch; Library Name: MyLibrary.IntLib; Manufacturer Part Number: RC1206JR-0710KL; Max Operating Temperature: 155°C; Min Operating Temperature: -55°C; Number of Terminations: 2; Package Quantity: 1; Packaging: Cut Tape; Power Rating: 250mW; Pricing 1: 5000=0,0034, 20000=0,0033, 75000=0,0031, 200000=0,003, 500000=0,0029 (USD); Pricing 2: 1=0,1, 10=0,024, 50=0,022, 100=0,0194, 500=0,01224, 1000=0,0102 (USD); Reach SVHC Compliant: Unknown; Resistance Tolerance: 5%; Resistor Type: Thick Film; RoHS: Yes; RoHS Compliant: Yes; Series: RC1206; Stock 1: 145000; Stock 2: 179700; Supplier 1: Future Electronics; Supplier 2: Digikey; Supplier Part Number 1: 4750733; Supplier Part Number 2: 311-10KERCT-ND; Termination: SMD/SMT; Tolerance: 5%; Voltage Rating (AC): 200V; Width: 0.063inch
U1 = TPS54331DR: 3.5V to 28V Input, 3A, 570kHz Step-Down Converter with Eco-mode 8-SOIC -40 to 150; Manufacturer: Texas Instruments; DesignedBy: Aslı Kurt; Max Output Voltage: 25V; Min Output Voltage: 800mV; Number of Channels: 1NUMBER; Number of Outputs: 1; Output Configuration: Positive; Output Type: Adjustable; Quiescent Current: 110uA; Synchronous Rectifier: No; Case/Package: D; Category: Voltage Regulators - Switching; Component Kind: Standard; ComponentLink1Description: https://datasheet.ciiva.com/41462/001014850-da-01-en-ic-reg-buck-adj-3a-tps54331dr-soic-8-tid-41462698.pdf?src-supplier=Conrad; ComponentLink1URL: https://datasheet.ciiva.com/41462/001014850-da-01-en-ic-reg-buck-adj-3a-tps54331dr-soic-8-tid-41462698.pdf?src-supplier=Conrad; ComponentLink2Description: https://datasheet.ciiva.com/2034/tps54331-2034841.pdf?src-supplier=Digikey; ComponentLink2URL: https://datasheet.ciiva.com/2034/tps54331-2034841.pdf?src-supplier=Digikey; ComponentLink3Description: https://datasheet.ciiva.com/2034/tps54331-2034840.pdf?src-supplier=Digikey; ComponentLink3URL: https://datasheet.ciiva.com/2034/tps54331-2034840.pdf?src-supplier=Digikey; ComponentLink4Description: https://datasheet.ciiva.com/2034/tps54331-2034842.pdf?src-supplier=Digikey; ComponentLink4URL: https://datasheet.ciiva.com/2034/tps54331-2034842.pdf?src-supplier=Digikey; ComponentLink5Description: https://datasheet.ciiva.com/14303/tps54331-14303672.pdf?src-supplier=Avnet; ComponentLink5URL: https://datasheet.ciiva.com/14303/tps54331-14303672.pdf?src-supplier=Avnet; Height: 1.5mm; Lead Free: Lead Free; Length: 5mm; Library Name: Regulators.IntLib; Manufacturer Part Number: TPS54331DR; Max Operating Temperature: 150°C; Max Input Voltage: 28V; Min Operating Temperature: -40°C; Min Input Voltage: 3.5V; Number of Pins: 8; Operating Supply Voltage: 28V; Output Current: 3A; Output Voltage: 25V; Package Quantity: 1; Packaging: Tape and Reel; Pricing 1: 1=1,87, 10=1,322, 25=1,1864, 100=1,0368, 250=0,96548, 500=0,92248, 1000=0,88708 (USD); Radiation Hardening: No; Reach SVHC Compliant: No; RoHS: Yes; RoHS Compliant: Yes; Series: Eco-Mode™; Stock 1: 4154; Supplier 1: Digikey; Supplier Part Number 1: 296-26991-6-ND; Switching Frequency: 570kHz; Thickness: 1.58mm; Weight: 0.002561oz; Width: 4mm
J3 J4 = 2x1 Male Header 2.54mm: Component Kind: Standard; Library Name: Connectors.IntLib
